# Altium schematic sheet LIDO-HW.300.DisplayInterface.SchDoc(LIDO-HW.300.DisplayInterface)
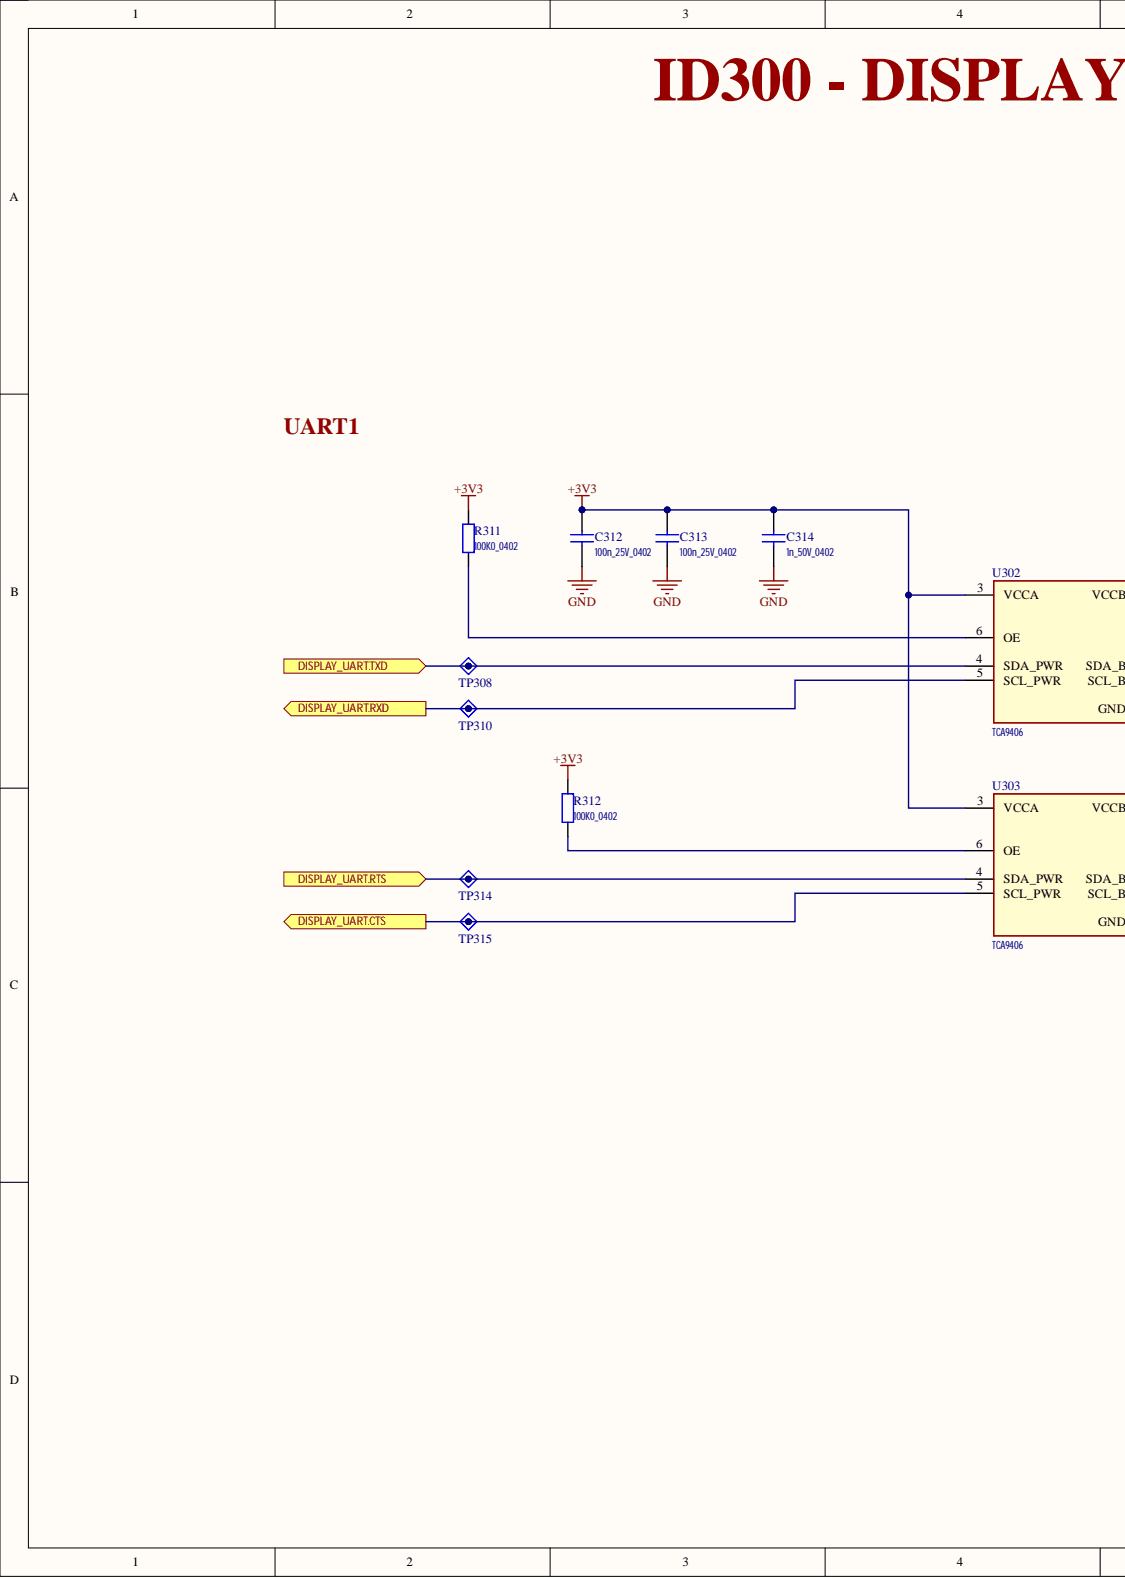
[diagram p0: LIDO-HW.300.DisplayInterface.SchDoc, left]
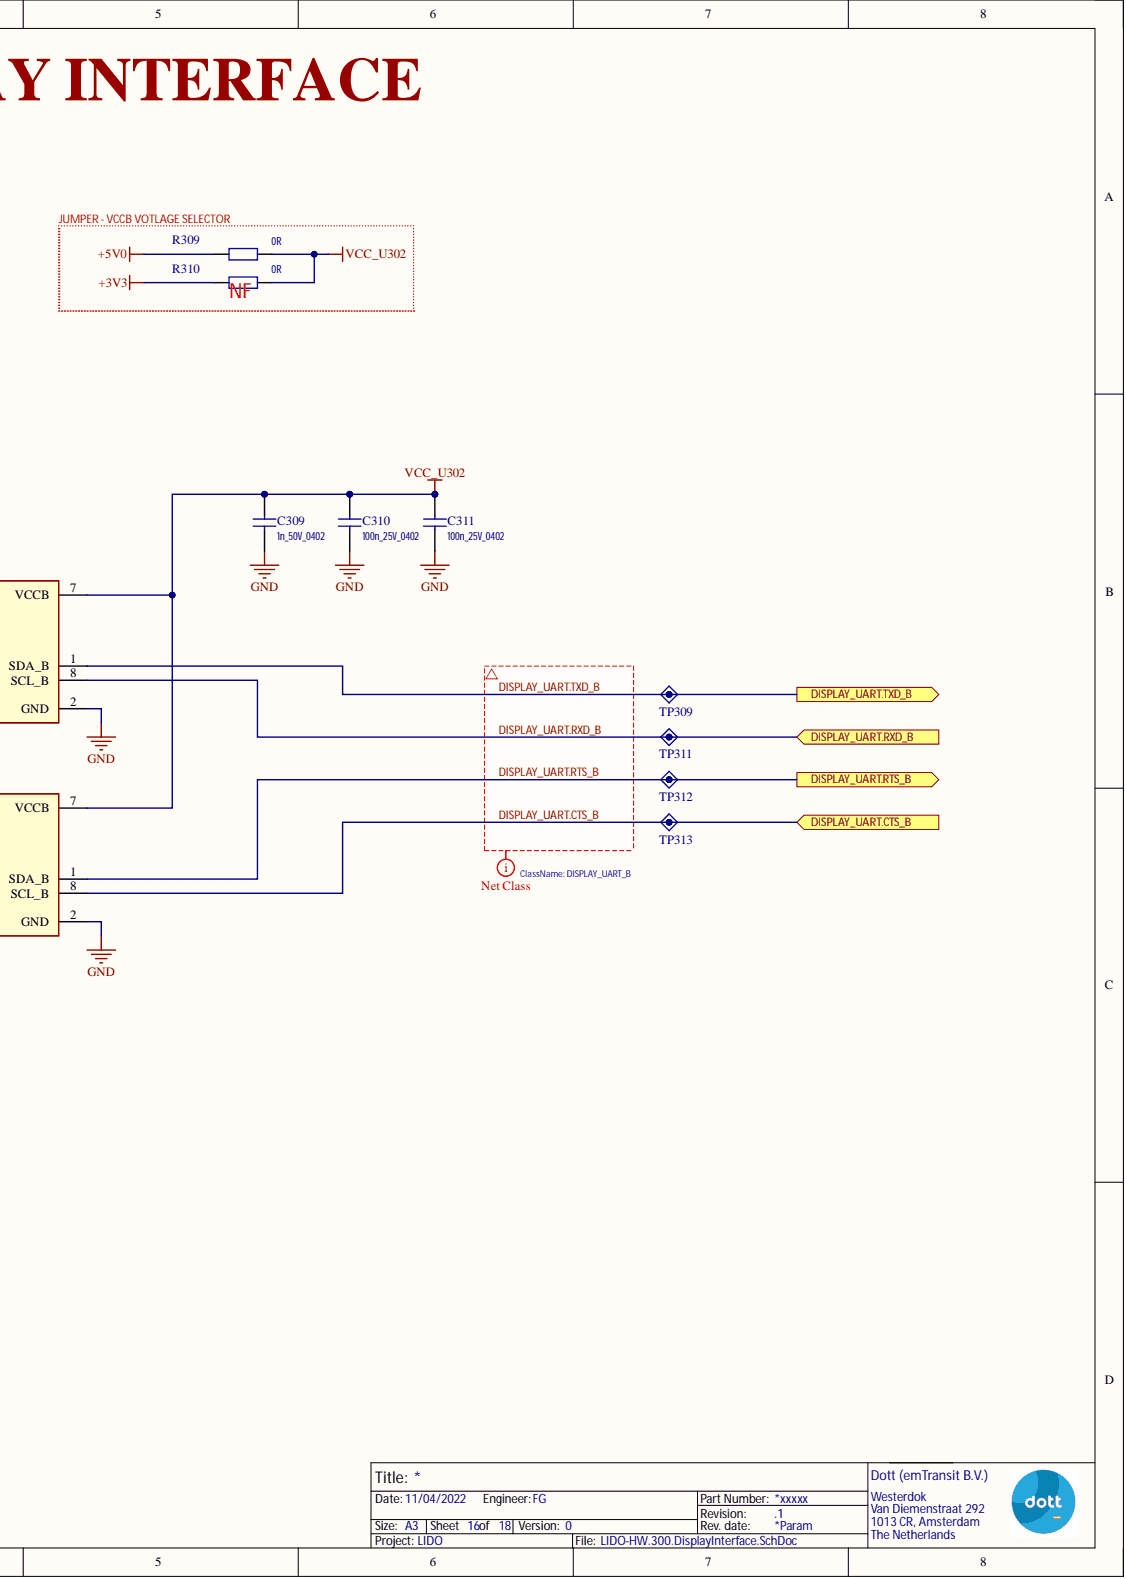
[diagram p1: LIDO-HW.300.DisplayInterface.SchDoc, right]

## Parts
C309  1n_50V_0402
C310  100n_25V_0402
C311  100n_25V_0402
C312  100n_25V_0402
C313  100n_25V_0402
C314  1n_50V_0402
R309  0R
R310  0R
R311  100K0_0402
R312  100K0_0402
TP308  TestPoint_PWR  (value not drawn)  (no BOM)
TP309  TestPoint_PWR  (value not drawn)  (no BOM)
TP310  TestPoint_PWR  (value not drawn)  (no BOM)
TP311  TestPoint_PWR  (value not drawn)  (no BOM)
TP312  TestPoint_PWR  (value not drawn)  (no BOM)
TP313  TestPoint_PWR  (value not drawn)  (no BOM)
TP314  TestPoint_PWR  (value not drawn)  (no BOM)
TP315  TestPoint_PWR  (value not drawn)  (no BOM)
U302  TCA9406
U303  TCA9406

## Ports
DISPLAY_UART.CTS, DISPLAY_UART.CTS_B, DISPLAY_UART.RTS, DISPLAY_UART.RTS_B, DISPLAY_UART.RXD, DISPLAY_UART.RXD_B, DISPLAY_UART.TXD, DISPLAY_UART.TXD_B

## Nets
+3V3: C312.1 C313.1 C314.1 R310.1 R311.1 R312.1 U302.3(VCCA) U303.3(VCCA)
+5V0: R309.1
GND: C309.2 C310.2 C311.2 C312.2 C313.2 C314.2 U302.2(GND) U303.2(GND)
VCC_U302: C309.1 C310.1 C311.1 R309.2 R310.2 U302.7(VCCB) U303.7(VCCB)
DISPLAY_UART.CTS: TP315.1 U303.5(SCL_PWR) ; port DISPLAY_UART.CTS
DISPLAY_UART.CTS_B: TP313.1 U303.8(SCL_B) ; port DISPLAY_UART.CTS_B
DISPLAY_UART.RTS: TP314.1 U303.4(SDA_PWR) ; port DISPLAY_UART.RTS
DISPLAY_UART.RTS_B: TP312.1 U303.1(SDA_B) ; port DISPLAY_UART.RTS_B
DISPLAY_UART.RXD: TP310.1 U302.5(SCL_PWR) ; port DISPLAY_UART.RXD
DISPLAY_UART.RXD_B: TP311.1 U302.8(SCL_B) ; port DISPLAY_UART.RXD_B
DISPLAY_UART.TXD: TP308.1 U302.4(SDA_PWR) ; port DISPLAY_UART.TXD
DISPLAY_UART.TXD_B: TP309.1 U302.1(SDA_B) ; port DISPLAY_UART.TXD_B
NetR311_2: R311.2 U302.6(OE)
NetR312_2: R312.2 U303.6(OE)

## Library data of the parts
C309-C314 = Ceramic: Ceramic CAP Symbol; Component Kind: Standard; Library Name: 01_Capacitors.SchLib
R309-R312 = Resistor: Resistor Symbol; Component Kind: Standard; Library Name: 02_Resistors.SchLib
TP308-TP315 = TestPoint_A: Test Point Symbol verion A; 00_Name: TP_XXmm; 01_Diameter: 1mm; Library Name: 31_MFG_Elements.SchLib
U302 U303 = TCA9406_A: 2-Bit Bidirectional 1-MHz, I  2C Bus and SMBus Voltage-Level Translator With 8-kV HBM ESD; Component Kind: Standard; Library Name: 15_IC_Logic.SchLib
